# Altium schematic sheet pyClock.SchDoc(pyClock)
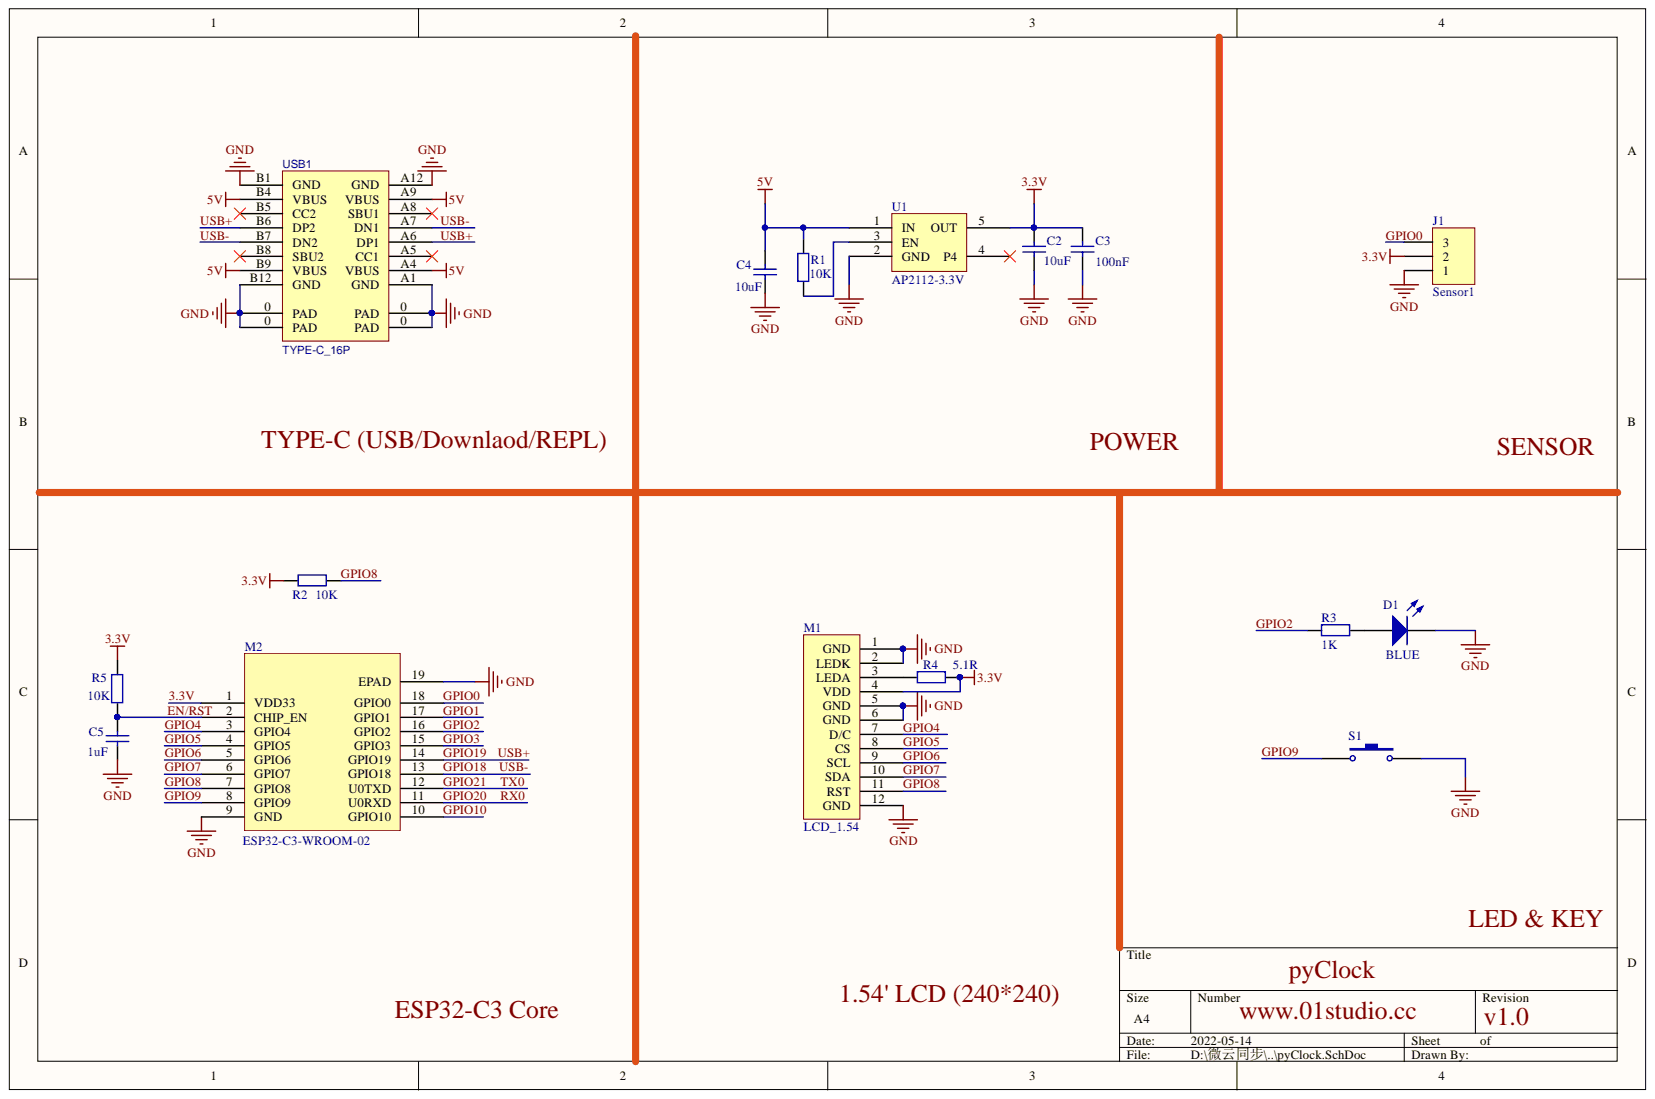
[diagram p0: pyClock.SchDoc]

## Parts
C2  10uF  [0603p]
C3  100nF  [0603p]
C4  10uF  [0603p]
C5  1uF  [0603p]
D1  BLUE  [D0603]
J1  Sensor1  [XH-1.25MM-3P弯针]
M1  LCD_1.54  [1.54寸LCD]
M2  ESP32-C3-WROOM-02  [ESP32-C3-WROOM-02]
R1  10K  [0603p]
R2  10K  [0603p]
R3  1K  [0603p]
R4  5.1R  [0603P]
R5  10K  [0603p]
S1  (no value)  [侧插按键开关 3*6*5]
U1  AP2112-3.3V  [SOT23-5]
USB1  TYPE-C_16P  [USB-TYPE-C_16PIN-MALE-TYPE-C-31-M-12]

## Nets
5V: C4.2 R1.1 U1.1(IN) USB1.A4(VBUS) USB1.A9(VBUS) USB1.B4(VBUS) USB1.B9(VBUS)
GND: C2.1 C3.1 C4.1 C5.1 D1.2 J1.1 M1.1(GND) M1.2(LEDK) M1.5(GND) M1.6(GND) M1.12(GND) M2.9(GND) M2.19(EPAD) S1.1 U1.2(GND) USB1.0(PAD) USB1.A1(GND) USB1.A12(GND) USB1.B1(GND) USB1.B12(GND)
3.3V: C2.2 C3.2 J1.2 M1.4(VDD) M2.1(VDD33) R2.1 R4.1 R5.2 U1.5(OUT)
EN/RST: C5.2 M2.2(CHIP_EN) R5.1
GPIO0: J1.3 M2.18(GPIO0)
GPIO1: M2.17(GPIO1)
GPIO2: M2.16(GPIO2) R3.1
GPIO3: M2.15(GPIO3)
GPIO4: M1.7(D/C) M2.3(GPIO4)
GPIO5: M1.8(CS) M2.4(GPIO5)
GPIO6: M1.9(SCL) M2.5(GPIO6)
GPIO7: M1.10(SDA) M2.6(GPIO7)
GPIO8: M1.11(RST) M2.7(GPIO8) R2.2
GPIO9: M2.8(GPIO9) S1.2
GPIO10: M2.10(GPIO10)
GPIO18: M2.13(GPIO18) USB1.A7(DN1) USB1.B7(DN2)
GPIO19: M2.14(GPIO19) USB1.A6(DP1) USB1.B6(DP2)
GPIO20: M2.11(U0RXD)
GPIO21: M2.12(U0TXD)
NetD1_1: D1.1 R3.2
NetM1_3: M1.3(LEDA) R4.2
NetR1_2: R1.2 U1.3(EN)

Not connected: U1 4(P4); USB1 A5(CC1) A8(SBU1) B5(CC2) B8(SBU2)

## Library data of the parts
C2-C5 = Cap: Capacitor; Simulation: CAP; Signal Integrity: Cap; Published: 8-Jun-2000; PackageReference: RAD-0.3; Library Name: Miscellaneous Devices.IntLib; LatestRevisionNote: Re-released for DXP Platform.; LatestRevisionDate: 17-Jul-2002; Component Kind: Standard; Publisher: Altium Limited
D1 = LED1: Typical RED GaAs LED; Simulation: LED1; Published: 8-Jun-2000; PackageReference: LED-1; Library Name: Miscellaneous Devices.IntLib; LatestRevisionNote: Mech Layer 1 removed.; LatestRevisionDate: 08-Jul-2005; Component Kind: Standard; Publisher: Altium Limited
J1 = Header 3: Header, 3-Pin; Signal Integrity: Connector; Library Name: Miscellaneous Connectors.IntLib; LatestRevisionNote: Re-released for DXP Platform.; LatestRevisionDate: 17-Jul-2002; Component Kind: Standard; Publisher: Altium Limited
R1-R5 = Res2: Resistor; Simulation: RESISTOR; Signal Integrity: Res; Published: 8-Jun-2000; PackageReference: AXIAL-0.4; Library Name: Miscellaneous Devices.IntLib; LatestRevisionNote: Re-released for DXP Platform.; LatestRevisionDate: 17-Jul-2002; Component Kind: Standard; Publisher: Altium Limited
S1 = SW-PB: Switch; Published: 24-Mar-1999; PackageReference: SPST-2; Library Name: Miscellaneous Devices.IntLib; LatestRevisionNote: Re-released for DXP Platform.; LatestRevisionDate: 17-Jul-2002; Component Kind: Standard; Publisher: Altium Limited
U1 = AP2112: Library Name: 01Studio.SchLib; Component Kind: Standard
USB1 = USB3-TypeC-16p-2: Component Kind: Standard
M1 = LCD_1.54: Library Name: Schlib1.SchLib; Component Kind: Standard
M2 = ESP32-C3-WROOM-02: Library Name: 01Studio.SchLib; Component Kind: Standard
